# Altium schematic sheet Sheet1.SchDoc
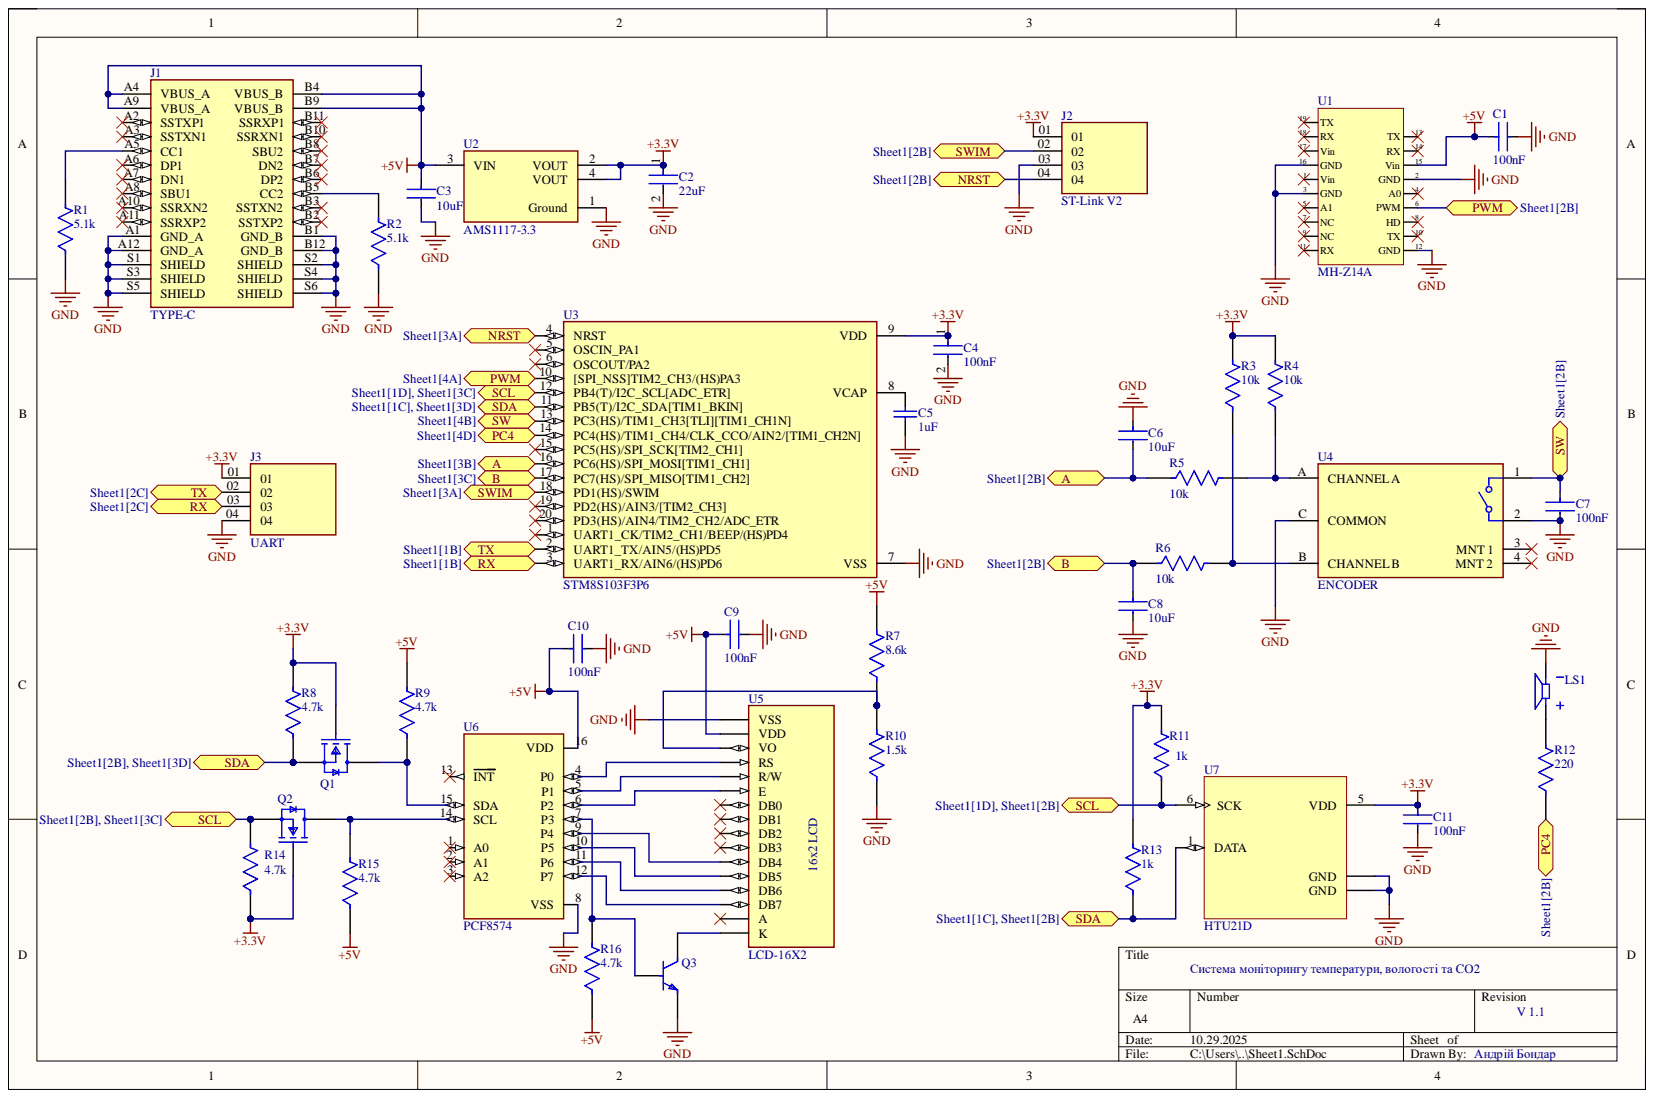
[diagram p0: Sheet1.SchDoc]

## Parts
C1  100nF  [FP-AC1206-0_85-MFG]
C2  22uF  [FP-CGA5L-0_3-0_1-MFG]
C3  10uF  [FP-C3216-160-0_2-IPC_B]
C4  100nF  [FP-AC1206-0_85-MFG]
C5  1uF  [CAPC1206(3216)150_L]
C6  10uF  [FP-C3216-160-0_2-IPC_B]
C7  100nF  [FP-AC1206-0_85-MFG]
C8  10uF  [FP-C3216-160-0_2-IPC_B]
C9  100nF  [FP-AC1206-0_85-MFG]
C10  100nF  [FP-AC1206-0_85-MFG]
C11  100nF  [FP-AC1206-0_85-MFG]
J1  TYPE-C  [GCT_USB4081-03-A_REV0.1]
J2  ST-Link V2  [SAMTEC_MTSW-104-07-G-S-200]
J3  UART  [SAMTEC_MTSW-104-07-G-S-200]
LS1  CPI-148-24-90T-67  [XDCR_CPI-148-24-90T-67]  (value not drawn)
Q1  BSS138  [FP-318-08-IPC_B]  (value not drawn)
Q2  BSS138  [FP-318-08-IPC_B]  (value not drawn)
Q3  SS8050DBU  [FP-135AN-MFG]  (value not drawn)
R1  5.1k  [FP-ESR18-IPC_A]
R2  5.1k  [FP-ESR18-IPC_A]
R3  10k  [FP-SR1206-MFG]
R4  10k  [FP-SR1206-MFG]
R5  10k  [FP-SR1206-MFG]
R6  10k  [FP-SR1206-MFG]
R7  8.6k  [FP-RP732B-MFG]
R8  4.7k  [FP-CRCW1206-Pe3-IPC_A]
R9  4.7k  [FP-CRCW1206-Pe3-IPC_A]
R10  1.5k  [FP-RMCF1206-MFG]
R11  1k  [FP-RMCF1206-IPC_A]
R12  220  [FP-SR1206-MFG]
R13  1k  [FP-RMCF1206-IPC_A]
R14  4.7k  [FP-CRCW1206-Pe3-IPC_A]
R15  4.7k  [FP-CRCW1206-Pe3-IPC_A]
R16  4.7k  [FP-CRCW1206-Pe3-IPC_A]
U1  MH-Z14A  [MH-Z14A]
U2  AMS1117-3.3  [FP-AMS1117-IPC_C]
U3  STM8S103F3P6  [SOP65P640X120-20N]
U4  ENCODER  [FP-PEC11R-4115F-S0018-MFG]
U5  LCD-16X2  [MODULE_LCD-16X2]
U6  PCF8574  [SOP65P640X150-20N]
U7  HTU21D  [QFN100P300X300X100-7N]

## Ports
A, B, NRST, PC4, PWM, RX, SCL, SDA, SW, SWIM, TX

## Nets
+3.3V: C2.1 C4.1 C11.1 J2.01 J3.01 Q1.1 Q2.1 R3.2 R4.2 R8.2 R11.2 R13.2 R14.1 U2.2(VOUT) U2.4(VOUT) U3.9(VDD) U7.5(VDD)
+5V: C1.1 C3.1 C9.1 C10.1 J1.A4(VBUS_A) J1.A9(VBUS_A) J1.B4(VBUS_B) J1.B9(VBUS_B) R7.2 R9.2 R15.1 R16.1 U1.15(Vin) U2.3(VIN) U5.2(VDD) U6.16(VDD)
GND: C1.2 C2.2 C3.2 C4.2 C5.1 C6.1 C7.2 C8.2 C9.2 C10.2 C11.2 J1.A1(GND_A) J1.A12(GND_A) J1.B1(GND_B) J1.B12(GND_B) J1.S1(SHIELD) J1.S2(SHIELD) J1.S3(SHIELD) J1.S4(SHIELD) J1.S5(SHIELD) J1.S6(SHIELD) J2.03 J3.04 LS1.N Q3.1 R1.1 R2.1 R10.1 U1.2(GND) U1.3(GND) U1.12(GND) U1.16(GND) U2.1(Ground) U3.7(VSS) U4.2 U4.C(COMMON) U5.1(VSS) U6.8(VSS) U7.2(GND) U7.PAD(GND)
A: C6.2 R5.1 U3.16(PC6(HS)/SPI_MOSI[TIM1_CH1]) ; port A
B: C8.1 R6.1 U3.17(PC7(HS)/SPI_MISO[TIM1_CH2]) ; port B
NRST: J2.04 U3.4(NRST) ; port NRST
PC4: R12.1 U3.14(PC4(HS)/TIM1_CH4/CLK_CCO/AIN2/[TIM1_CH2N]) ; port PC4
PWM: U1.6(PWM) U3.10([SPI_NSS]TIM2_CH3/(HS)PA3) ; port PWM
RX: J3.03 U3.3(UART1_RX/AIN6/(HS)PD6) ; port RX
SCL: Q2.2 R11.1 R14.2 U3.12(PB4(T)/I2C_SCL[ADC_ETR]) U7.6(SCK) ; port SCL
SDA: Q1.2 R8.1 R13.1 U3.11(PB5(T)/I2C_SDA[TIM1_BKIN]) U7.1(DATA) ; port SDA
SW: C7.1 U3.13(PC3(HS)/TIM1_CH3[TLI][TIM1_CH1N]) U4.1 ; port SW
SWIM: J2.02 U3.18(PD1(HS)/SWIM) ; port SWIM
TX: J3.02 U3.2(UART1_TX/AIN5/(HS)PD5) ; port TX
NetC5_2: C5.2 U3.8(VCAP)
NetJ1_A5: J1.A5(CC1) R1.2
NetJ1_B5: J1.B5(CC2) R2.2
NetLS1_P: LS1.P R12.2
NetQ1_3: Q1.3 R9.1 U6.15(SDA)
NetQ2_3: Q2.3 R15.2 U6.14(SCL)
NetQ3_2: Q3.2 R16.2 U6.7(P3)
NetQ3_3: Q3.3 U5.16(K)
NetR3_1: R3.1 R6.2 U4.B(CHANNEL_B)
NetR4_1: R4.1 R5.2 U4.A(CHANNEL_A)
NetR7_1: R7.1 R10.2 U5.3(VO)
NetU5_4: U5.4(RS) U6.4(P0)
NetU5_5: U5.5(R/W) U6.5(P1)
NetU5_6: U5.6(E) U6.6(P2)
NetU5_11: U5.11(DB4) U6.9(P4)
NetU5_12: U5.12(DB5) U6.10(P5)
NetU5_13: U5.13(DB6) U6.11(P6)
NetU5_14: U5.14(DB7) U6.12(P7)

Not connected: J1 A2(SSTXP1) A3(SSTXN1) A6(DP1) A7(DN1) A8(SBU1) A10(SSRXN2) A11(SSRXP2) B2(SSTXP2) B3(SSTXN2) B6(DP2) B7(DN2) B8(SBU2) B10(SSRXN1) B11(SSRXP1); U1 1(Vin) 4(A0) 5(A1) 7(NC) 8(HD) 9(NC) 10(TX) 11(RX) 13(TX) 14(RX) 17(Vin) 18(RX) 19(TX); U3 1(UART1_CK/TIM2_CH1/BEEP/(HS)PD4) 5(OSCIN_PA1) 6(OSCOUT/PA2) 15(PC5(HS)/SPI_SCK[TIM2_CH1]) 19(PD2(HS)/AIN3/[TIM2_CH3]) 20(PD3(HS)/AIN4/TIM2_CH2/ADC_ETR); U4 3(MNT_1) 4(MNT_2); U5 7(DB0) 8(DB1) 9(DB2) 10(DB3) 15(A); U6 1(A0) 2(A1) 3(A2) 13(INT)

## Library data of the parts
C1 C4 C7 C9-C11 = CMP-03422-001145-1: CAP CER 0.1UF 100V X7R 1206; Manufacturer: Yageo; Manufacturer Part Number: AC1206KKX7R0BB104; Automotive Grade: Grade 1; Material: Ceramic; Temperature Coefficient: 15%; Tolerance: 0.1; Automotive: Yes; Capacitance: 100 nF; Category: Cap; Component Kind: Standard; ComponentLink2Description: Datasheet; ComponentLink2URL: http://www.yageo.com/documents/recent/UPY-AC_NP0X7R_16V-to-630V_12.pdf; Device Class L1: Passive Components; Device Class L2: Capacitors; Device Class L3: Ceramic Capacitors; Digikey Description: CAP CER 0.1UF 100V X7R 1206; Footprint Url: http://www.yageo.com/exep/pages/download/literatures/UPY-C_GEN_24.pdf; Height: 0.95mm; Ipc Land Pattern Name: CAPC320160X85; Lead Free: Yes; Library Name: Altium Content Vault; Octopart: https://octopart.com/ac1206kkx7r0bb104-yageo-75666120; Package: AC1206-0.85; RoHS: TRUE; Temperature: -55°C to +125°C; Temperature Characteristic: X7R; Temperature Range High: +125°C; Temperature Range Low: -55°C; Value: 100 nF; Voltage Rating: 100 V
C2 = CMP-08246-002069-1: Cap Ceramic 22uF 6.3V X7R 20% Pad SMD 1206 +125°C Automotive T/R; Capacitance: 22uF; Case Code (Imperial): 1206; Case Code (Metric): 3216; Case/Package: 1206; Component Kind: Standard; Composition: Ceramic; Depth: 1.6mm; Dielectric: X7R; Height: 1.3mm; Lead Free: Lead Free; Length: 3.2mm; Library Name: Altium Content Vault; Max Operating Temperature: 125°C; Min Operating Temperature: -55°C; Mount: Surface Mount; Package Quantity: 1; Packaging: Tape and Reel; Ratings: AEC-Q200; REACH SVHC: No SVHC; Termination: SMD/SMT; Thickness: 0.063inch; Tolerance: 20%; Voltage Rating: 6.3V; Voltage Rating (DC): 6.3V; Weight: 0.000571oz; Width: 0.063inch
C3 C6 C8 = CMP-08246-003840-1: Multilayer Ceramic Capacitors 10uF ±20% 25V X7R SMD 1206; Capacitance: 10uF; Case Code (Imperial): 1206; Case Code (Metric): 3216; Case/Package: 1206; Component Kind: Standard; Construction: Flat; Depth: 1.6mm; Dielectric: X7R; Dielectric Material: Ceramic; Height: 1.6mm; Lead Free: Lead Free; Length: 3.2mm; Library Name: Altium Content Vault; Max Operating Temperature: 125°C; Min Operating Temperature: -55°C; Mount: Surface Mount; Number of Pins: 2; Package Quantity: 2000; Packaging: Tape and Reel; Radiation Hardening: No; Resistor Type: Ceramic; RoHS Compliant: Yes; Series: C; Termination: SMD/SMT; Thickness: 0.075inch; Tolerance: 20%; Voltage Rating: 25V; Voltage Rating (DC): 25V; Weight: 0.000571oz; Width: 0.063inch
C5 = CMP-1037-04691-1: CAP 1uF 16V ±20% 1206 (3216 Metric) Thickness 1.45mm SMD; Case-EIA: 1206; Case-Metric: 3216; Tolerance: Â±20%; Component Kind: Standard; Library Name: Altium Content Vault; Max Thickness: 1.45 mm; Rated Voltage: 16 V; Technology: SMT; Value: 1uF
J1 = USB4081-03-A_REV0.1: Type C USB 3.2 Gen 2 Connector; MF: Global Connector Technology; Availability: In Stock; Check_prices: https://www.snapeda.com/parts/USB4081-03-A/Global+Connector+Technology/view-part/?ref=eda; Component Kind: Standard; Library Name: USB4081-03-A_REV0.1.SchLib; MP: USB4081-03-A; Package: None; Price: None; SnapEDA_Link: https://www.snapeda.com/parts/USB4081-03-A/Global+Connector+Technology/view-part/?ref=snap
J2 J3 = MTSW-104-07-G-S-200: Availability: Unavailable; Component Kind: Standard; Library Name: MTSW-104-07-G-S-200.IntLib; MP: MTSW-104-07-G-S-200; Package: None; Price: None
LS1 = CPI-148-24-90T-67: 13,9 Ð¼Ð¼, 24 Ð’ Ð¿Ð¾ÑÑ‚. Ñ‚Ð¾ÐºÐ°, 90 Ð´Ð‘, ÑÐºÐ²Ð¾Ð·Ð½Ð¾Ðµ Ð¾Ñ‚Ð²ÐµÑ€ÑÑ‚Ð¸Ðµ, Ð¿ÑŒÐµÐ·Ð¾ÑÐ»ÐµÐºÑ‚Ñ€Ð¸Ñ‡ÐµÑÐºÐ¸Ð¹ Ð·Ð²ÑƒÐºÐ¾Ð²Ð¾Ð¹ Ð¸Ð½Ð´Ð¸ÐºÐ°Ñ‚Ð¾Ñ€; MF: Same Sky; Check_prices: https://www.snapeda.com/parts/CPI-148-24-90T-67/Same+Sky/view-part/?ref=eda; Component Kind: Standard; Library Name: CPI-148-24-90T-67.IntLib; MP: CPI-148-24-90T-67; Package: None; SnapEDA_Link: https://www.snapeda.com/parts/CPI-148-24-90T-67/Same+Sky/view-part/?ref=snap
Q1 Q2 = CMP-07173-000101-1: MOSFET N-CH 50V 220MA SOT-23; Drain to Source Resistance: 3.5R; Element Configuration: Single; Fall Time: 9ns; Gate to Source Voltage (Vgs): 20V; Input Capacitance: 27pF; Max Power Dissipation: 360mW; Nominal Vgs: 1.3V; Number of Elements: 1; On-State Resistance: 6R; Turn-Off Delay Time: 20ns; Turn-On Delay Time: 2.5ns; Case/Package: SOT-23; Component Kind: Standard; Contact Plating: Tin; Continuous Drain Current (ID): 220mA; Current Rating: 220mA; Drain to Source Breakdown Voltage: 50V; Drain to Source Voltage (Vdss): 50V; Dual Supply Voltage: 50V; Height: 0.93mm; Lead Free: Lead Free; Length: 2.92mm; Library Name: Altium Content Vault; Max Operating Temperature: 150°C; Min Operating Temperature: -55°C; Mount: Surface Mount; Number of Pins: 3; Package Quantity: 1; Packaging: Tape and Reel; Power Dissipation: 360mW; Radiation Hardening: No; Rds On Max: 3.5R; REACH SVHC: No SVHC; Rise Time: 9ns; Termination: SMD/SMT; Threshold Voltage: 1.3V; Voltage Rating (DC): 50V; Weight: 0.03g; Width: 1.3mm
Q3 = CMP-00278-84331634-1: SS8050DBU Bipolar (BJT) Single Transistor, NPN, 25 V, 100 MHz, 1 W, 1.5 A, 160; Manufacturer Lifecycle Status: ACTIVE; Collector Base Voltage (VCBO): 40V; Collector Emitter Breakdown Voltage: 25V; Collector Emitter Saturation Voltage: 500mV; Current Rating: 1.5A; Emitter Base Voltage (VEBO): 6V; Frequency: 100MHz; hFE Min: 85; Max Junction Temperature (Tj): 150°C; Max Power Dissipation: 1W; Number of Elements: 1; Output Power: 2W; Case/Package: TO-92; Collector Emitter Voltage (VCEO): 25V; Component Kind: Standard; Element Configuration: Single; Gain Bandwidth Product: 100MHz; Height: 4.58mm; Lead Free: Lead Free; Length: 4.58mm; Library Name: Altium Content Vault; Lifecycle Status: Production; Max Collector Current: 1.5A; Max Frequency: 100MHz; Max Operating Temperature: 150°C; Min Operating Temperature: -65°C; Mount: Through Hole; NexarPartId: 84331634; Number of Pins: 3; Packaging: Bulk; Polarity: NPN; Power Dissipation: 1W; Radiation Hardening: No; REACH SVHC: No SVHC; RoHS: Compliant; Schedule B: 8541290080, 8541290080; Transition Frequency: 100MHz; Voltage Rating (DC): 25V; Weight: 179mg; Width: 3.86mm
R1 R2 = CMP-08839-028234-1: 5.1kΩ ±1% 0.5W 1206 Anti-Surge Chip Resistor AEC-Q200; Max Power Dissipation: 333mW; Resistance: 5.1kR; Temperature Coefficient: 100ppm/°C; Case/Package: 1206; Component Kind: Standard; Features: Automotive AEC-Q200; Height: 0.026inch; Length: 0.126inch; Library Name: Altium Content Vault; Max Operating Temperature: 155°C; Min Operating Temperature: -55°C; Number of Terminations: 2; Package Quantity: 5000; Packaging: Tape and Reel; Resistor Type: Thick Film; RoHS Compliant: Yes; Series: ESR18; Tolerance: 1%; Width: 0.063inch
R3-R6 = CMP-03412-033202-1: RES 10K OHM 1% 1/2W 1206; Manufacturer: Yageo; Manufacturer Part Number: SR1206FR-7W10KL; Automotive Grade: Grade 0; Power Rating: 0.5W; Resistance: 10KΩ; Tolerance: 0.01; Value: 10KΩ; Voltage Rating: 200V; Automotive: Yes; Category: Res; Component Kind: Standard; ComponentLink2Description: Datasheet; ComponentLink2URL: http://www.yageo.com/documents/recent/PYu-SR_D_F%20tol_RoHS_L_3.pdf; Composition: Thick Film; Device Class L1: Passive Components; Device Class L2: Resistors; Device Class L3: Chip SMD Resistors; Digikey Description: RES 10K OHM 1% 1/2W 1206; Footprint Url: http://www.yageo.com/exep/pages/download/literatures/PYu-R_Mount_10.pdf; Height: 0.65mm; Ipc Land Pattern Name: RESC310160X55; Lead Free: Yes; Library Name: Altium Content Vault; Octopart: https://octopart.com/sr1206fr-7w10kl-yageo-92673874; Package: SR1206; RoHS: TRUE; Series: SR; Temperature: -55°C to +155°C; Temperature Range High: +155°C; Temperature Range Low: -55°C
R7 = CMP-03211-003095-1: Res Thin Film 1206 8.66KΩ 0.1% 0.25W 15ppm/°C Molded SMD; Manufacturer: TE Connectivity; Manufacturer Part Number: RP73D2B8K66BTDF; Voltage Rating: 200V; Automotive: No; Category: Res; Component Kind: Standard; ComponentLink2Description: Datasheet; ComponentLink2URL: https://www.te.com/commerce/DocumentDelivery/DDEController?Action=showdoc&DocId=Data+Sheet%7F1773272%7FL%7Fpdf%7FEnglish%7FENG_DS_1773272_L.pdf%7F8-1879255-6; Composition: Thin Film; Device Class L1: Passive Components; Device Class L2: Resistors; Device Class L3: Chip SMD Resistors; Height: 0.65mm; Ipc Land Pattern Name: RESC305155X55; Lead Free: Yes; Library Name: Altium Content Vault; Octopart: https://octopart.com/rp73d2b8k66btdf-te+connectivity-22741248; octopart_url: https://octopart.com/rp73d2b8k66btdf-te+connectivity-22741248; Package: RP732B; packaging: 1000 Reel; Power Rating: 0.25W; Resistance: 8.66KΩ; RoHS: TRUE; Series: RP73; Temperature: -55°C to 155°C; Temperature Coefficient: 15ppm/°C; Temperature Range High: 155°C; Temperature Range Low: -55°C; Tolerance: 0.1%; Value: 8.66KΩ
R8 R9 R14-R16 = CMP-03613-000093-1: RES Thick Film, 4.7kΩ, 1%, 0.25W, 50ppm/°C, 1206; Max Power Dissipation: 250mW; Resistance: 4.7kR; Case/Package: 1206; Component Kind: Standard; Construction: Rectangular; Contact Plating: Tin; Depth: 1.6mm; Features: Automotive AEC-Q200; Height: 0.024inch; Length: 0.126inch; Library Name: Altium Content Vault; Max Operating Temperature: 155°C; Military Standard: Not; Min Operating Temperature: -55°C; Number of Terminations: 2; Package Quantity: 5000; Packaging: Tape and Reel; Radiation Hardening: No; Resistor Type: Thick Film; RoHS Compliant: Yes; Series: CRCW-P; Temperature Coefficient: 50ppm/°C; Tolerance: 1%; Width: 0.063inch
R10 = CMP-26527-000167-1: 1.5kΩ ±1% 0.25W 1206 Thick Film Chip Resistor AEC-Q200 compliant; Max Power Dissipation: 250mW; Resistance: 1.5kR; Temperature Coefficient: 100ppm/°C; Case Code (Imperial): 1206; Case Code (Metric): 3216; Case/Package: 1206; Component Kind: Standard; Construction: Rectangular; Contact Plating: Tin; Depth: 1.6mm; Features: Automotive AEC-Q200; Height: 0.028inch; Lead Free: Lead Free; Length: 0.126inch; Library Name: Altium Content Vault; Max Operating Temperature: 155°C; Military Standard: Not; Min Operating Temperature: -55°C; Mount: Surface Mount; Number of Terminations: 2; Package Quantity: 1; Packaging: Tape and Reel; Power Rating: 250mW; Radiation Hardening: No; Resistor Type: Thick Film; RoHS Compliant: Yes; Series: RMCF; Size Code: 1206; Tolerance: 1%; Width: 0.063inch
R11 R13 = CMP-26527-006126-1: 1kΩ ±5% 0.25W 1206 Thick Film Chip Resistor AEC-Q200 compliant; Max Power Dissipation: 250mW; Resistance: 1kR; Temperature Coefficient: 200ppm/°C; Case Code (Imperial): 1206; Case Code (Metric): 3216; Case/Package: 1206; Component Kind: Standard; Contact Plating: Tin; Features: Automotive AEC-Q200; Height: 0.028inch; Length: 0.126inch; Library Name: Altium Content Vault; Max Operating Temperature: 155°C; Min Operating Temperature: -55°C; Mount: Surface Mount; Number of Terminations: 2; Packaging: Tape and Reel; Radiation Hardening: No; Resistor Type: Thick Film; RoHS Compliant: Yes; Series: RMCF; Tolerance: 5%; Width: 0.063inch
R12 = CMP-03412-006046-1: RES SMD 220 OHM 1% 1/2W 1206; Manufacturer: Yageo; Manufacturer Part Number: SR1206FR-7W220RL; Automotive Grade: Grade 0; Power Rating: 0.5W; Resistance: 220Ω; Tolerance: 0.01; Value: 220Ω; Voltage Rating: 200V; Automotive: Yes; Category: Res; Component Kind: Standard; ComponentLink2Description: Datasheet; ComponentLink2URL: http://www.yageo.com/documents/recent/PYu-SR_D_F%20tol_RoHS_L_3.pdf; Composition: Thick Film; Device Class L1: Passive Components; Device Class L2: Resistors; Device Class L3: Chip SMD Resistors; Digikey Description: RES SMD 220 OHM 1% 1/2W 1206; Footprint Url: http://www.yageo.com/exep/pages/download/literatures/PYu-R_Mount_10.pdf; Height: 0.65mm; Ipc Land Pattern Name: RESC310160X55; Lead Free: Yes; Library Name: Altium Content Vault; Octopart: https://octopart.com/sr1206fr-7w220rl-yageo-71414967; Package: SR1206; RoHS: TRUE; Series: SR; Temperature: -55°C to +155°C; Temperature Range High: +155°C; Temperature Range Low: -55°C
U2 = CMP-209535-000001-1: LDO Voltage Regulators 1A, 3.3V; Manufacturer: Advanced Monolithic Systems; Dropout Voltage: 1.1V; Max Junction Temp: +125°C; Max Power Dissipation: 1.2W; Max Supply Voltage: 15V; Nominal Supply Current: 5mA; Number Of Outputs: 1; Output Type: Fixed; Standoff Height: 0.64mm; Automotive: No; Category: IC; Component Kind: Standard; ComponentLink2Description: Datasheet; ComponentLink2URL: http://www.advanced-monolithic.com/pdf/ds1117.pdf; Device Class L1: Integrated Circuits (ICs); Device Class L2: Power Management ICs; Device Class L3: Voltage Regulators - Linear; Height: 1.8mm; Ipc Land Pattern Name: SOT229P700X180-4; Lead Free: Yes; Library Name: Altium Content Vault; Manufacturer Part Number: AMS1117-3.3; Octopart: https://octopart.com/ams1117-3.3-advanced+monolithic+systems-25899300; Output Current: 1A; Output Voltage: 3.3V; Package: AMS1117; RoHS: TRUE; Temperature: -40°C to +125°C; Temperature Range High: +125°C; Temperature Range Low: -40°C
U3 = STM8S103F3P6: STM8 series Microcontroller IC 8-Bit 16MHz 8KB (8K x 8) FLASH 20-TSSOP; MF: STMicroelectronics; Check_prices: https://www.snapeda.com/parts/STM8S103F3P6/STMicroelectronics/view-part/?ref=eda; Component Kind: Standard; Library Name: STM8S103F3P6 (1).IntLib; MP: STM8S103F3P6; Package: TSSOP-20 STMicroelectronics; SnapEDA_Link: https://www.snapeda.com/parts/STM8S103F3P6/STMicroelectronics/view-part/?ref=snap
U4 = CMP-07421-000064-1: ROTARY ENCODER MECHANICAL 18PPR; Built in Switch: Yes; Hole Diameter: 1.8mm; Number of Channels: 2; Output Type: Quadrature; Pulses per Revolution: 18; Component Kind: Standard; Detent: Yes; Encoder Type: Mechanical; Height: 13.4mm; Length: 21.5mm; Library Name: Altium Content Vault; Max Operating Temperature: 70°C; Min Operating Temperature: -30°C; Mount: Through Hole; Number of Pins: 5; Orientation: Vertical; Package Quantity: 150; Packaging: Bulk; Radiation Hardening: No; REACH SVHC: No SVHC; RoHS Compliant: Yes; Series: PEC11R; Termination: PC Pins; Width: 12.5mm
U5 = LCD-16X2: Display Development Tools 16x2 Black on Green Char LCD w Backlight; MF: Gravitech; Check_prices: https://www.snapeda.com/parts/LCD-16X2/Gravitech/view-part/?ref=eda; Component Kind: Standard; Library Name: LCD-16X2.IntLib; MP: LCD-16X2; Package: None; SnapEDA_Link: https://www.snapeda.com/parts/LCD-16X2/Gravitech/view-part/?ref=snap
U6 = PCF8574: I/O Expander 8 IÂ²C 100 kHz 20-VQFN (3.5x4.5); MF: Texas Instruments; Check_prices: https://www.snapeda.com/parts/PCF8574/Texas+Instruments/view-part/?ref=eda; Component Kind: Standard; Library Name: PCF8574.IntLib; MP: PCF8574; Package: VFQFN-16 Texas Instruments; SnapEDA_Link: https://www.snapeda.com/parts/PCF8574/Texas+Instruments/view-part/?ref=snap
U7 = HTU21D: Humidity Temperature Sensor 0 ~ 100% RH IÂ²C Â±2% RH 5s Surface Mount; MF: TE Connectivity; Availability: Unavailable; Component Kind: Standard; ComponentLink1Description: New link; Library Name: SnapEDAsch.SchLib; MP: HTU21D; Package: DFN-6 TE Connectivity; Price: None; SnapEDA_Link: https://www.snapeda.com/parts/HTU21D/TE%20Connectivity%20ALCOSWITCH%20Switches/view-part/562219/?ref=snap; TE_purchase_URL: https://www.te.com/usa-en/product-CAT-HSC0004.html?te_bu=Cor&te_type=disp&te_campaign=seda_glo_cor-seda-global-disp-prtnr-fy19-seda-model-bom-cta_sma-317_1&elqCampaignId=32493
U1 = MH-Z14A: Component Kind: Standard; Library Name: Schlib1.SchLib
